# Altium schematic sheet Power Monitor.SchDoc
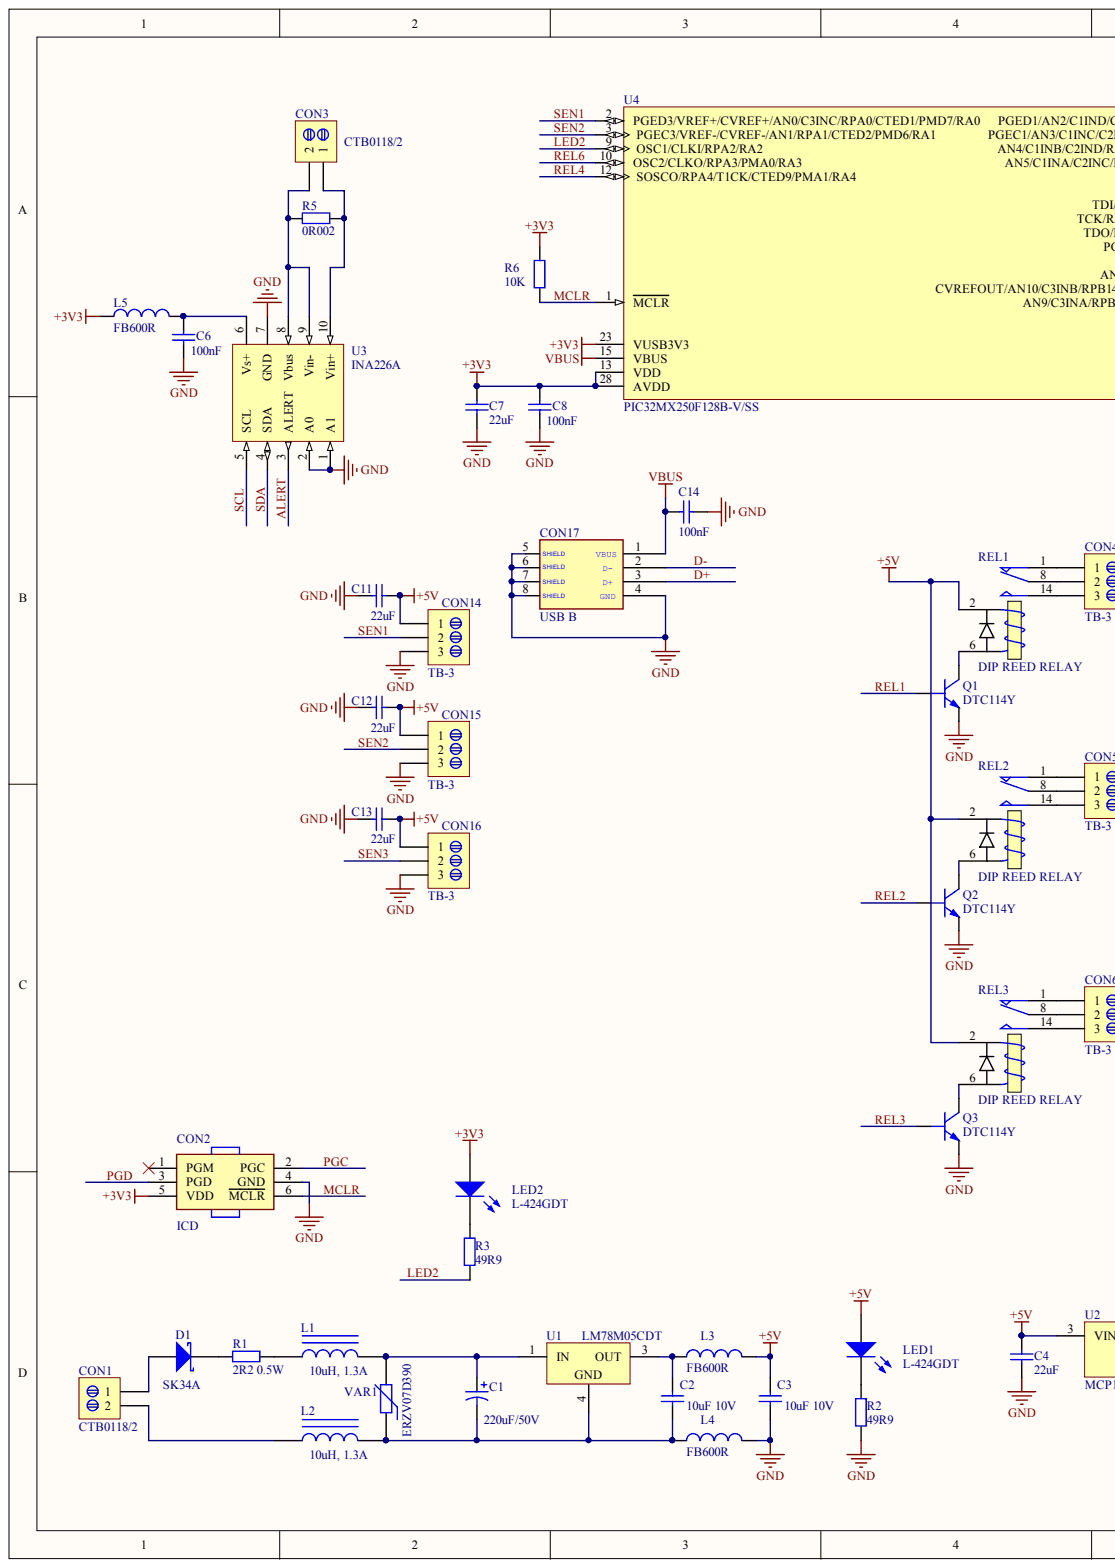
[diagram p0: Power Monitor.SchDoc, left]
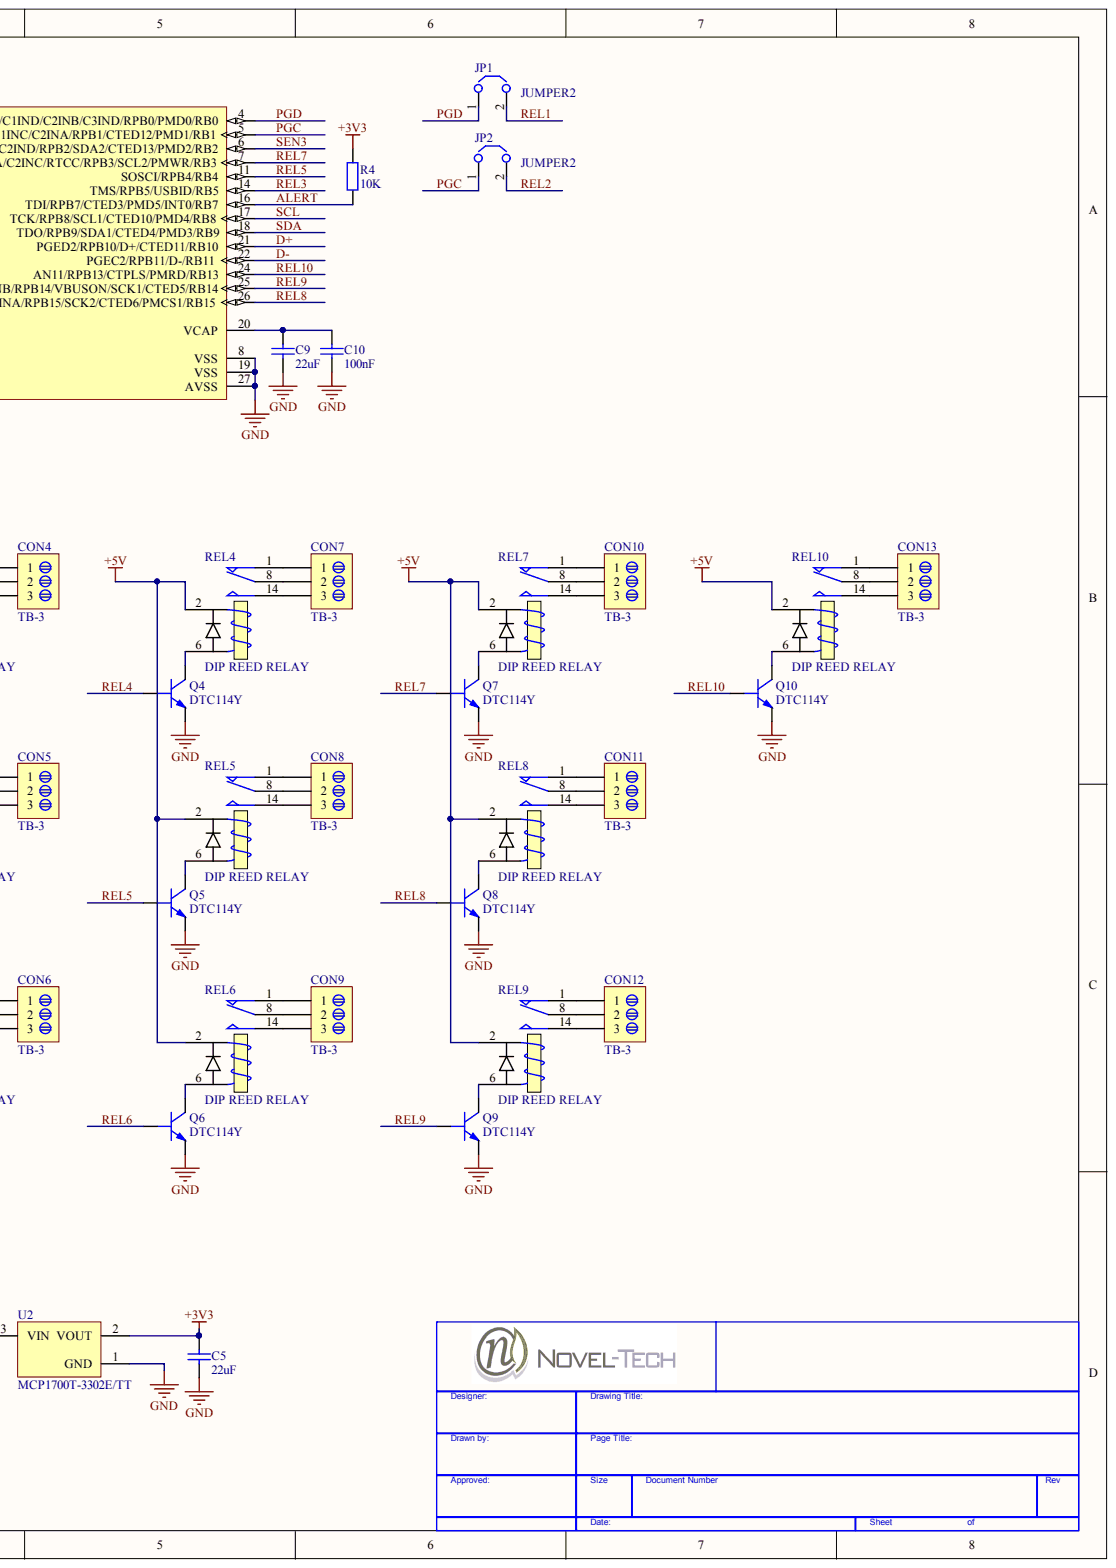
[diagram p1: Power Monitor.SchDoc, right]

## Parts
*  TitleBlock  (value not drawn)
C1  220uF/50V  [CAPP5X12.5]
C2  10uF 10V  value 10uF  [CAPC2012N]
C3  10uF 10V  value 10uF  [CAPC2012N]
C4  22uF  [CAPC2012N]
C5  22uF  [CAPC2012N]
C6  100nF  [CAPC1005N]
C7  22uF  [CAPC2012N]
C8  100nF  [CAPC1005N]
C9  22uF  [CAPC2012N]
C10  100nF  [CAPC1005N]
C11  22uF  [CAPC2012N]
C12  22uF  [CAPC2012N]
C13  22uF  [CAPC2012N]
C14  100nF  [CAPC1005N]
CON1  CTB0118/2  [TB-5.08-2]
CON2  ICD  [HEADER 2X3-1.27mm]
CON3  CTB0118/2  [TB-5.08-2]
CON4  TB-3  [TB-5.08-3]
CON5  TB-3  [TB-5.08-3]
CON6  TB-3  [TB-5.08-3]
CON7  TB-3  [TB-5.08-3]
CON8  TB-3  [TB-5.08-3]
CON9  TB-3  [TB-5.08-3]
CON10  TB-3  [TB-5.08-3]
CON11  TB-3  [TB-5.08-3]
CON12  TB-3  [TB-5.08-3]
CON13  TB-3  [TB-5.08-3]
CON14  TB-3  [TB-5.08-3]
CON15  TB-3  [TB-5.08-3]
CON16  TB-3  [TB-5.08-3]
CON17  USB B  [USB-B-V]
D1  SK34A  [SMA-DO214AA]
JP1  JUMPER2  [HDR1X2-2.54mm]
JP2  JUMPER2  [HDR1X2-2.54mm]
L1  10uH, 1.3A  [INDUCTOR 4x4mm]
L2  10uH, 1.3A  [INDUCTOR 4x4mm]
L3  FB600R  [RESC2012N]
L4  FB600R  [RESC2012N]
L5  FB600R  [RESC2012N]
LED1  L-424GDT  [3MM LED]
LED2  L-424GDT  [3MM LED]
Q1  DTC114Y  [SOT23]
Q2  DTC114Y  [SOT23]
Q3  DTC114Y  [SOT23]
Q4  DTC114Y  [SOT23]
Q5  DTC114Y  [SOT23]
Q6  DTC114Y  [SOT23]
Q7  DTC114Y  [SOT23]
Q8  DTC114Y  [SOT23]
Q9  DTC114Y  [SOT23]
Q10  DTC114Y  [SOT23]
R1  2R2 0.5W  [RES1W_A]
R2  49R9  [RESC1005N]
R3  49R9  [RESC1005N]
R4  10K  [RESC1005N]
R5  0R002  [RESC6332N]
R6  10K  [RESC1005N]
REL1  DIP REED RELAY  [REED RELAY DIP]
REL2  DIP REED RELAY  [REED RELAY DIP]
REL3  DIP REED RELAY  [REED RELAY DIP]
REL4  DIP REED RELAY  [REED RELAY DIP]
REL5  DIP REED RELAY  [REED RELAY DIP]
REL6  DIP REED RELAY  [REED RELAY DIP]
REL7  DIP REED RELAY  [REED RELAY DIP]
REL8  DIP REED RELAY  [REED RELAY DIP]
REL9  DIP REED RELAY  [REED RELAY DIP]
REL10  DIP REED RELAY  [REED RELAY DIP]
U1  LM78M05CDT  [TD03B_N]
U2  MCP1700T-3302E/TT  [SOT-23-TT3_N]
U3  INA226A  [MSOP-10]
U4  PIC32MX250F128B-V/SS  [MCHP-SSOP-SS28_N]  (value not drawn)
VAR1  ERZV07D390  [VAR7.5MM]

## Nets
+3V3: C5.1 C7.1 C8.1 CON2.5(VDD) L5.1 LED2.1 R4.1 R6.1 U2.2 U4.13(VDD) U4.23(VUSB3V3) U4.28(AVDD)
+5V: C3.2 C4.1 C11.1 C12.1 C13.1 CON14.1 CON15.1 CON16.1 L3.2 LED1.1 REL1.2 REL2.2 REL3.2 REL4.2 REL5.2 REL6.2 REL7.2 REL8.2 REL9.2 REL10.2 U2.3
GND: C3.1 C4.2 C5.2 C6.2 C7.2 C8.2 C9.2 C10.2 C11.2 C12.2 C13.2 C14.2 CON2.4(GND) CON14.3 CON15.3 CON16.3 CON17.4(GND) CON17.5(SHIELD) CON17.6(SHIELD) CON17.7(SHIELD) CON17.8(SHIELD) L4.2 Q1.2 Q2.2 Q3.2 Q4.2 Q5.2 Q6.2 Q7.2 Q8.2 Q9.2 Q10.2 R2.2 U2.1 U3.1(A1) U3.2(A0) U3.7(GND) U4.8(VSS) U4.19(VSS) U4.27(AVSS)
VBUS: C14.1 CON17.1(VBUS) U4.15(VBUS)
ALERT: R4.2 U3.3(ALERT) U4.16(TDI/RPB7/CTED3/PMD5/INT0/RB7)
D+: CON17.3(D+) U4.21(PGED2/RPB10/D+/CTED11/RB10)
D-: CON17.2(D-) U4.22(PGEC2/RPB11/D-/RB11)
LED2: R3.2 U4.9(OSC1/CLKI/RPA2/RA2)
MCLR: CON2.6(MCLR) R6.2 U4.1(MCLR)
PGC: CON2.2(PGC) JP2.1 U4.5(PGEC1/AN3/C1INC/C2INA/RPB1/CTED12/PMD1/RB1)
PGD: CON2.3(PGD) JP1.1 U4.4(PGED1/AN2/C1IND/C2INB/C3IND/RPB0/PMD0/RB0)
REL1: JP1.2 Q1.1
REL2: JP2.2 Q2.1
REL3: Q3.1 U4.14(TMS/RPB5/USBID/RB5)
REL4: Q4.1 U4.12(SOSCO/RPA4/T1CK/CTED9/PMA1/RA4)
REL5: Q5.1 U4.11(SOSCI/RPB4/RB4)
REL6: Q6.1 U4.10(OSC2/CLKO/RPA3/PMA0/RA3)
REL7: Q7.1 U4.7(AN5/C1INA/C2INC/RTCC/RPB3/SCL2/PMWR/RB3)
REL8: Q8.1 U4.26(AN9/C3INA/RPB15/SCK2/CTED6/PMCS1/RB15)
REL9: Q9.1 U4.25(CVREFOUT/AN10/C3INB/RPB14/VBUSON/SCK1/CTED5/RB14)
REL10: Q10.1 U4.24(AN11/RPB13/CTPLS/PMRD/RB13)
SCL: U3.5(SCL) U4.17(TCK/RPB8/SCL1/CTED10/PMD4/RB8)
SDA: U3.4(SDA) U4.18(TDO/RPB9/SDA1/CTED4/PMD3/RB9)
SEN1: CON14.2 U4.2(PGED3/VREF+/CVREF+/AN0/C3INC/RPA0/CTED1/PMD7/RA0)
SEN2: CON15.2 U4.3(PGEC3/VREF-/CVREF-/AN1/RPA1/CTED2/PMD6/RA1)
SEN3: CON16.2 U4.6(AN4/C1INB/C2IND/RPB2/SDA2/CTED13/PMD2/RB2)
NetC1_1: C1.1 L1.2 U1.1 VAR1.2
NetC1_2: C1.2 C2.1 L2.2 L4.1 U1.4 VAR1.1
NetC2_2: C2.2 L3.1 U1.3
NetC6_1: C6.1 L5.2 U3.6(Vs+)
NetC9_1: C9.1 C10.1 U4.20(VCAP)
NetCON1_1: CON1.1 D1.1
NetCON1_2: CON1.2 L2.1
NetCON3_1: CON3.1 R5.2 U3.10(Vin+)
NetCON3_2: CON3.2 R5.1 U3.8(Vbus) U3.9(Vin-)
NetCON4_1: CON4.1 REL1.1
NetCON4_2: CON4.2 REL1.8
NetCON4_3: CON4.3 REL1.14
NetCON5_1: CON5.1 REL2.1
NetCON5_2: CON5.2 REL2.8
NetCON5_3: CON5.3 REL2.14
NetCON6_1: CON6.1 REL3.1
NetCON6_2: CON6.2 REL3.8
NetCON6_3: CON6.3 REL3.14
NetCON7_1: CON7.1 REL4.1
NetCON7_2: CON7.2 REL4.8
NetCON7_3: CON7.3 REL4.14
NetCON8_1: CON8.1 REL5.1
NetCON8_2: CON8.2 REL5.8
NetCON8_3: CON8.3 REL5.14
NetCON9_1: CON9.1 REL6.1
NetCON9_2: CON9.2 REL6.8
NetCON9_3: CON9.3 REL6.14
NetCON10_1: CON10.1 REL7.1
NetCON10_2: CON10.2 REL7.8
NetCON10_3: CON10.3 REL7.14
NetCON11_1: CON11.1 REL8.1
NetCON11_2: CON11.2 REL8.8
NetCON11_3: CON11.3 REL8.14
NetCON12_1: CON12.1 REL9.1
NetCON12_2: CON12.2 REL9.8
NetCON12_3: CON12.3 REL9.14
NetCON13_1: CON13.1 REL10.1
NetCON13_2: CON13.2 REL10.8
NetCON13_3: CON13.3 REL10.14
NetD1_2: D1.2 R1.1
NetL1_1: L1.1 R1.2
NetLED1_2: LED1.2 R2.1
NetLED2_2: LED2.2 R3.1
NetQ1_3: Q1.3 REL1.6
NetQ2_3: Q2.3 REL2.6
NetQ3_3: Q3.3 REL3.6
NetQ4_3: Q4.3 REL4.6
NetQ5_3: Q5.3 REL5.6
NetQ6_3: Q6.3 REL6.6
NetQ7_3: Q7.3 REL7.6
NetQ8_3: Q8.3 REL8.6
NetQ9_3: Q9.3 REL9.6
NetQ10_3: Q10.3 REL10.6

Not connected: CON2 1(PGM)

## Library data of the parts
C1 = CAPACITOR_TANTAL_SMD: Component Kind: Standard; PCB3D: TANTAL-A; Library Name: Darko.SCHLIB
C2-C14 = Cap: Capacitor; Component Kind: Standard; LatestRevisionDate: 17-Jul-2002; LatestRevisionNote: Re-released for DXP Platform.; Library Name: Miscellaneous Devices.IntLib; PackageReference: RAD-0.3; Published: 8-Jun-2000; Signal Integrity: Cap; Simulation: CAP; Publisher: Altium Limited
  C2 C3: PCB3D: C0805
  C4 C5 C7 C9 C11-C13: PCB3D: C0805; Value: 22uF
  C6 C8 C14: PCB3D: C0402
  C10: PCB3D: C0402; Value: 100nF
CON1 CON3 = TB-2: Component Kind: Standard; Library Name: Darko.SCHLIB
CON2 = PIC-ICD: BD095-06A-A0-0200-0070-0570-LG; Component Kind: Standard; Library Name: Darko.SCHLIB
CON17 = USB CON: Component Kind: Standard; Library Name: Darko.SCHLIB
D1 = D Schottky: Schottky Diode; Code_JEDEC: DO-214-AA; Component Kind: Standard; LatestRevisionDate: 17-Jul-2002; LatestRevisionNote: Re-released for DXP Platform.; Library Name: Miscellaneous Devices.IntLib; PackageReference: SMB; Published: 8-Jun-2000; Signal Integrity: D Schottky; Simulation: SKYDIODE; Publisher: Altium Limited
L1 L2 = Inductor Iron: NRS4018T100MDGJ; Component Kind: Standard; LatestRevisionDate: 17-Jul-2002; LatestRevisionNote: Re-released for DXP Platform.; Library Name: Miscellaneous Devices.IntLib; PackageDescription: Axial Device, Thru-Hole; 2 Leads; 0.9 in Pin Spacing; PackageReference: AXIAL-0.9; Published: 24-Mar-1999; Signal Integrity: Inductor Iron; Simulation: INDUCTOR; Value: NRS4018T100MDGJ; Publisher: Altium Limited
L3-L5 = Inductor: Code_IEC: 1005; Code_JEITA: 0402; Component Kind: Standard; Description: Inductor; LatestRevisionDate: 29-May-2009; LatestRevisionNote: IPC-7351 Footprint Added.; Library Name: Miscellaneous Devices.IntLib; PackageDescription: Chip Inductor; PackageReference: 0402-A; Published: 8-Jun-2000; Signal Integrity: Inductor; Simulation: INDUCTOR; Publisher: Altium Limited
LED1 LED2 = LED DIODE: Component Kind: Standard; PCB3D: LED3MM_LED; Library Name: Darko.SCHLIB
Q1-Q10 = NPN TRANSISTOR: Component Kind: Standard; Library Name: Darko.SCHLIB
R1 = Res2: MCKNP0W2J0100A10; Component Kind: Standard; LatestRevisionDate: 17-Jul-2002; LatestRevisionNote: Re-released for DXP Platform.; Library Name: Miscellaneous Devices.IntLib; PackageReference: AXIAL-0.4; PCB3D: R0603; Published: 8-Jun-2000; Signal Integrity: Res; Simulation: RESISTOR; Value: 2R2 0.5W; Publisher: Altium Limited
R2-R6 = Res2: Resistor; Component Kind: Standard; LatestRevisionDate: 17-Jul-2002; LatestRevisionNote: Re-released for DXP Platform.; Library Name: Miscellaneous Devices.IntLib; PackageReference: AXIAL-0.4; PCB3D: R0603; Published: 8-Jun-2000; Signal Integrity: Res; Simulation: RESISTOR; Publisher: Altium Limited
  R2 R3: Value: 49R9
  R5: Value: 0R002
REL1-REL10 = Reed Relay DIP: Component Kind: Standard; Library Name: Darko.SCHLIB
U1 = LM78M05CDT: 3-Terminal Positive Voltage Regulators, 3-pin TO-252; Manufacturer: National Semiconductor; CompType: LDO IC; PackageTopMarking: NSUZXYTT*LM78M05*CDT; PowerWise: N; Code_JEDEC: TO-252-AA; Component Kind: Standard; ComponentLink1Description: LM78M05 Datasheet; ComponentLink1URL: http://www.national.com/ds/LM/LM78M05.pdf; ComponentLink2Description: LM78M05 Web Page; ComponentLink2URL: http://www.national.com/pf/LM/LM78M05.html; ComponentLink3Description: TD03B Package Page; ComponentLink3URL: http://www.national.com/packaging/folders/td03b.html; DatasheetVersion: Aug-2005; Library Name: NSC LDO.IntLib; PackageDescription: TO252, Molded, Jedec, 6.8 x 6.10 x 2.30mm Body, 3 Lead, 2.28mm Pitch, Surface Mount; PackageReference: TD03B; PackageVersion: revD, Feb-2009; PartNumber: LM78M05CDT; PinCount: 3; Published: 4-Dec-2008; RoHS: N; Publisher: Altium Limited
U2 = MCP1700T-3302E/TT: Low Quiescent Current LDO, 3-Pin SOT-23, Extended Temperature, Tape and Reel; Manufacturer: Microchip; Code_IPC: SOT23-3; Component Kind: Standard; ComponentLink1Description: Manufacturer URL; ComponentLink1URL: http://www.microchip.com/; ComponentLink2Description: Datasheet; ComponentLink2URL: http://ww1.microchip.com/downloads/en/DeviceDoc/21826b.pdf; ComponentLink3Description: Package Specification; ComponentLink3URL: http://www.microchip.com/stellent/groups/techpub_sg/documents/packagingspec/en012702.pdf; DatasheetVersion: revB, Feb-2007; Library Name: Microchip Power Management Line Regulator.IntLib; Mounting Technology: Surface Mount; PackageDescription: 3-Lead Plastic Small Outline Transistor (TT) [SOT-23]; PackageReference: SOT-23-TT3; PackageVersion: revBB, Aug-2009; PartNumber: MCP1700T-3302E/TT; Supplier 1: Digi-Key; Supplier 2: Digi-Key; Supplier 3: Digi-Key; Supplier 4: Farnell; Supplier 5: Farnell; Supplier 6: Farnell; Supplier 7: Newark; Supplier 8: Newark; Supplier 9: Mouser; Supplier Part Number 1: MCP1700T3302ETTTR-ND; Supplier Part Number 2: MCP1700T3302ETTCT-ND; Supplier Part Number 3: MCP1700T3302ETTDKR-ND; Supplier Part Number 4: 1296592; Supplier Part Number 5: 1296592RL; Supplier Part Number 6: 2133944; Supplier Part Number 7: 88H9817; Supplier Part Number 8: 23R8107; Supplier Part Number 9: 579-MCP1700T3302E/TT
U4 = PIC32MX250F128B-V/SS: 32-bit Microcontroller with Audio and Graphics Interface, USB and Advanced Analog, 50 MHz, 19 I/O, PMP, 28-pin SSOP (SS28), Tube; Manufacturer: Microchip; Component Kind: Standard; ComponentLink1Description: Manufacturer URL; ComponentLink1URL: http://www.microchip.com; ComponentLink2Description: Datasheet; ComponentLink2URL: http://ww1.microchip.com/downloads/en/DeviceDoc/61168E.pdf; ComponentLink3Description: Package Specification; ComponentLink3URL: http://www.microchip.com/stellent/groups/techpub_sg/documents/packagingspec/en012702.pdf; ComponentLink4Description: Product URL; ComponentLink4URL: http://www.microchip.com/PIC32MX250F128B; DatasheetVersion: Rev. E; Library Name: Microchip PIC32MX.IntLib; Mounting Technology: Surface Mount; PackageDescription: 28-Lead Plastic Shrink Small Outline, 10.2 x 5.3 mm Body, 0.65 mm Pitch; PackageReference: SSOP-SS28; PackageVersion: C04-073B; PartNumber: PIC32MX250F128B-V/SS; Supplier 1: Digi-Key; Supplier 2: Newark; Supplier 3: Mouser; Supplier Part Number 1: PIC32MX250F128B-V/SS-ND; Supplier Part Number 2: 73T1458; Supplier Part Number 3: 579-32MX250F128BVSS
VAR1 = Res Varistor: Code_IPC: RESC2012; Component Kind: Standard; Description: ERZV07D390; LatestRevisionDate: 29-May-2009; LatestRevisionNote: IPC-7351 Footprint Added.; Library Name: Miscellaneous Devices.IntLib; PackageDescription: Chip Resistor 0805 Case Size; PackageReference: 6-0805; PackageVersion: Mar-2003; Published: 24-Mar-1999; Publisher: Altium Limited
* = TitleBlock: Component Kind: Standard; Library Name: Darko.SCHLIB
CON4-CON16 = TB-3: Component Kind: Standard; Library Name: Darko.SCHLIB
JP1 JP2 = JUMPER2: Component Kind: Standard; Library Name: Darko.SCHLIB
U3 = INA226A: Component Kind: Standard; Library Name: Darko.SCHLIB
